# Altium schematic sheet STM32F103.SchDoc
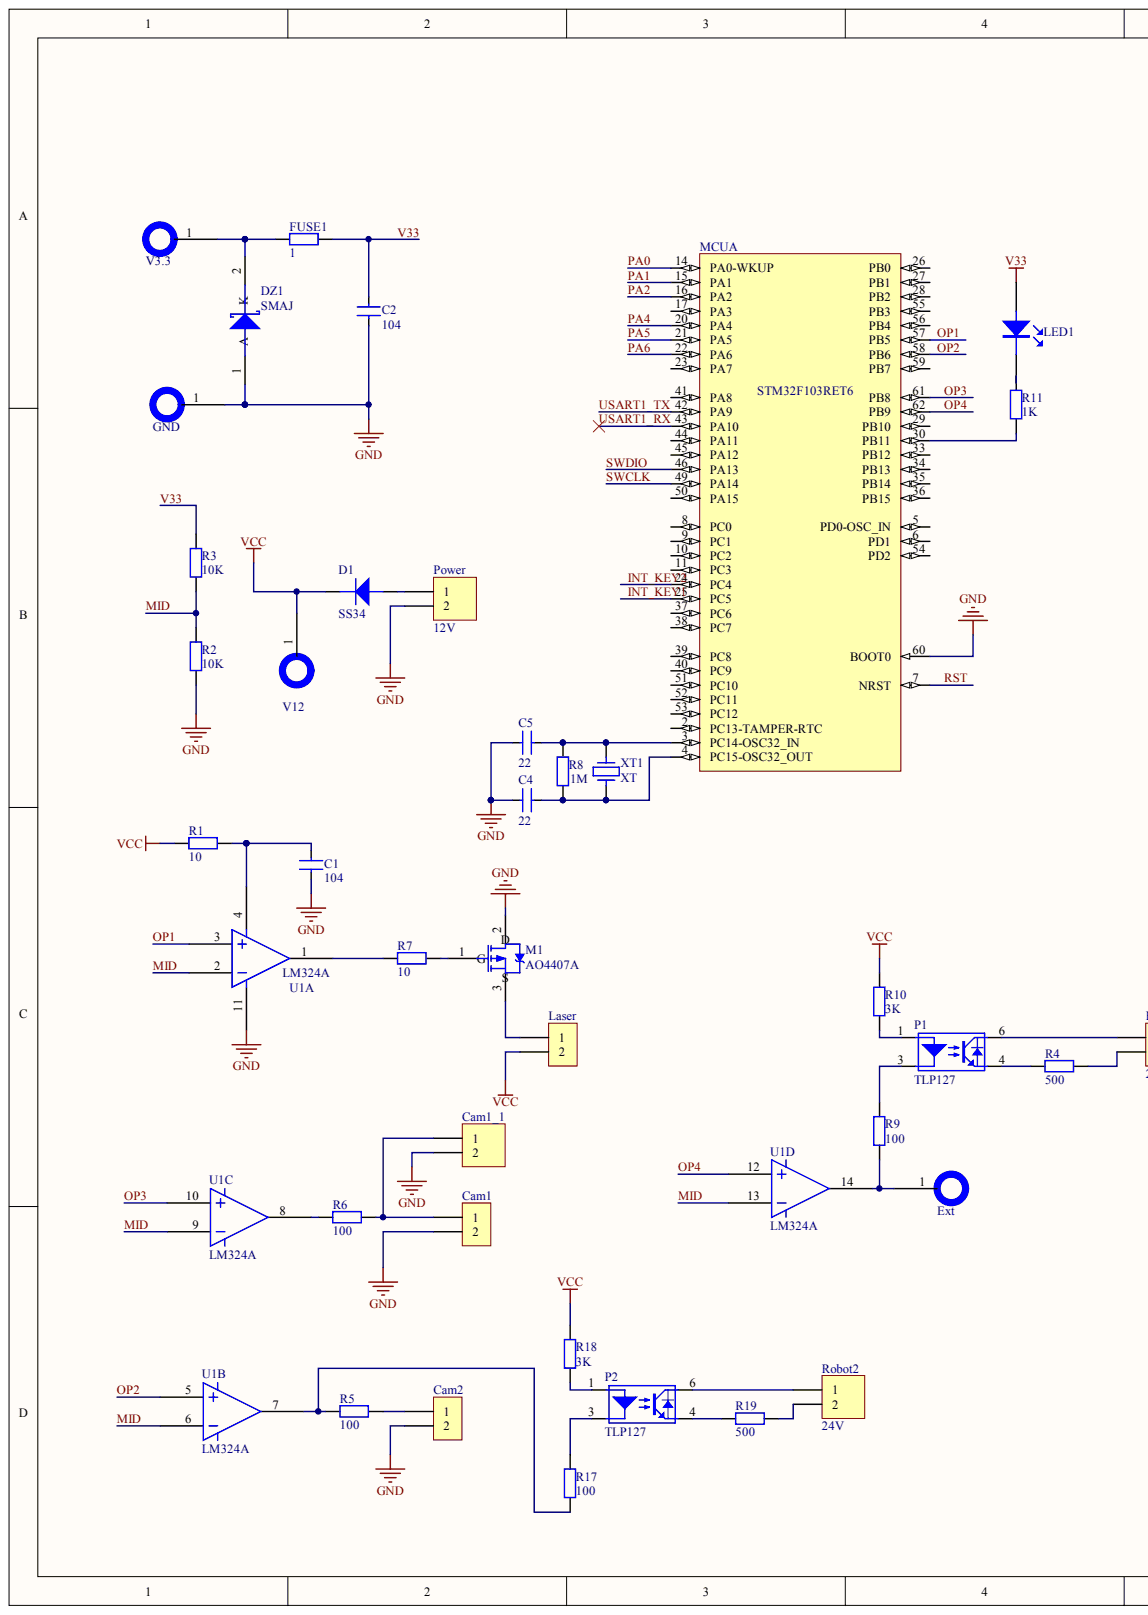
[diagram p0: STM32F103.SchDoc, left]
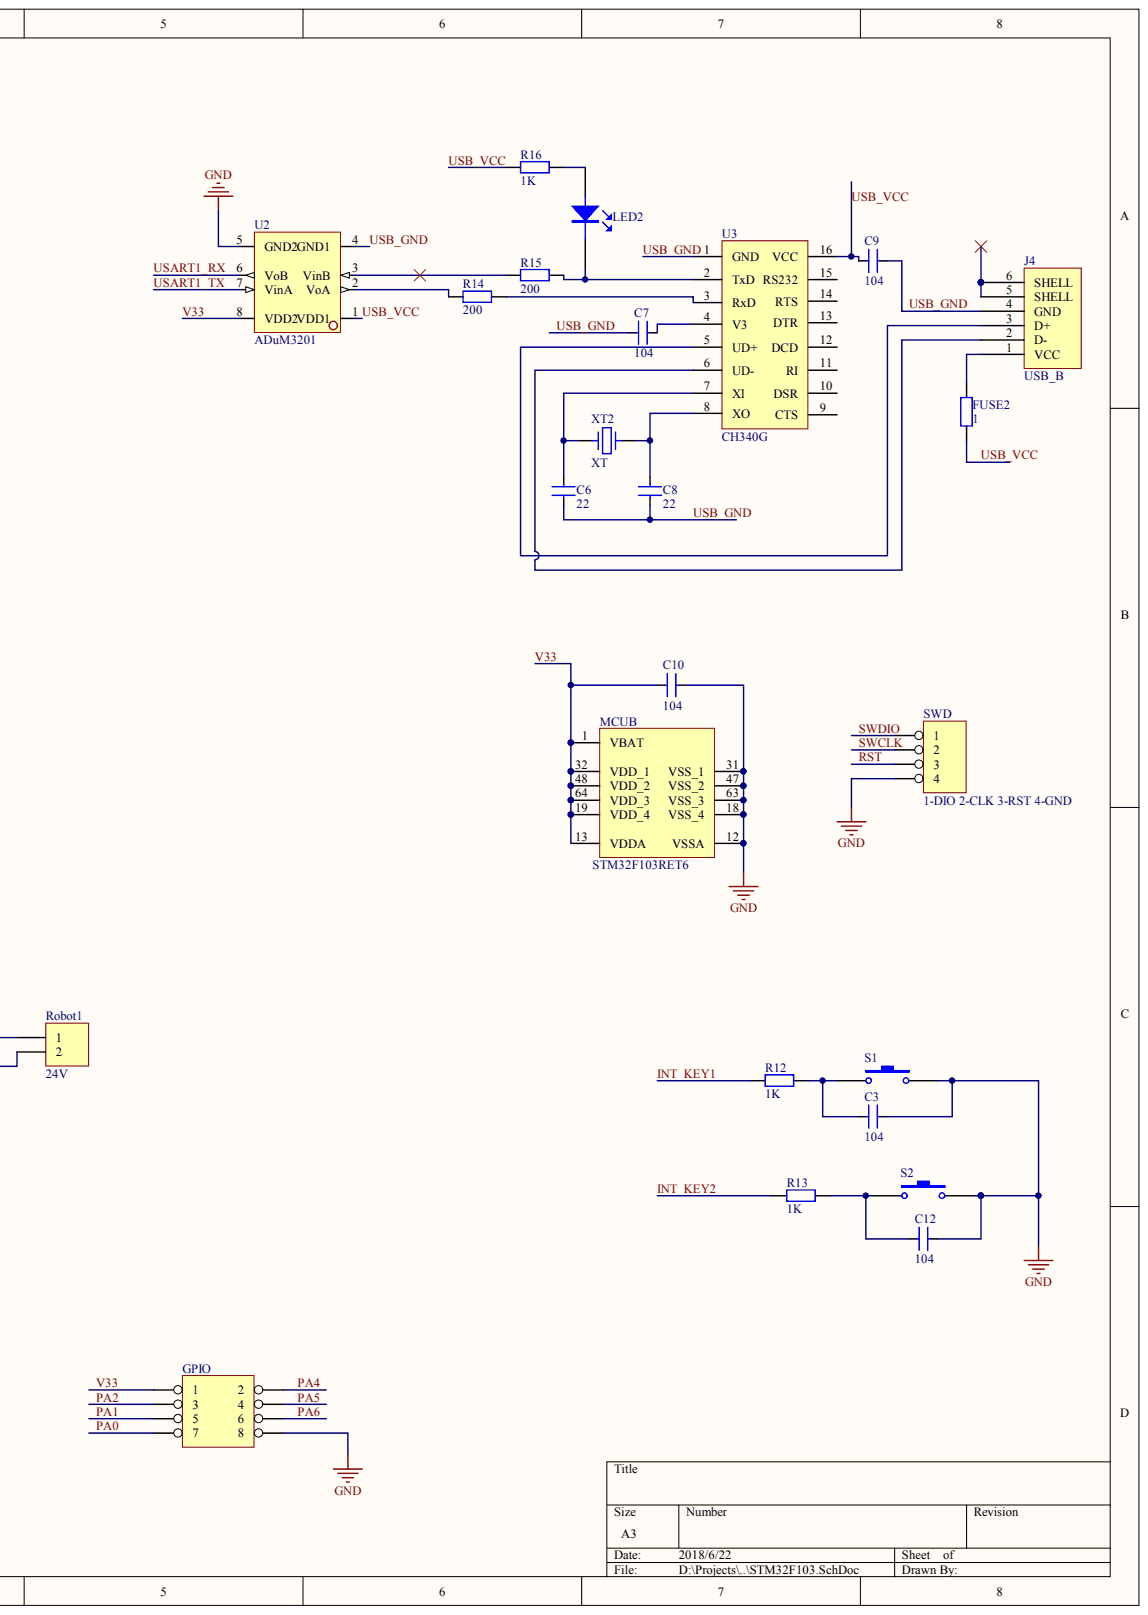
[diagram p1: STM32F103.SchDoc, right]

## Parts
C1  C  value 104
C2  C  value 104
C3  C  value 104
C4  C  value 22
C5  C  value 22
C6  C  value 22
C7  C  value 104
C8  C  value 22
C9  C  value 104
C10  C  value 104
C12  C  value 104
Cam1  Cam1
Cam1_1  (no value)
Cam2  (no value)
D1  SS34
DZ1  SMAJ
Ext  Hole  (value not drawn)
FUSE1  value 1
FUSE2  value 1
GND  Hole  (value not drawn)
GPIO  (no value)
J4  USB_B
Laser  (no value)
LED1  LED
LED2  LED
M1  AO4407A
MCU  STM32F103RET6  (parts A,B)
P1  TLP127
P2  TLP127
Power  12V
R1  value 10  (hidden comment: Res)
R2  value 10K  (hidden comment: Res)
R3  value 10K  (hidden comment: Res)
R4  value 500  (hidden comment: Res)
R5  value 100  (hidden comment: Res)
R6  value 100  (hidden comment: Res)
R7  value 10  (hidden comment: Res)
R8  value 1M  (hidden comment: Res)
R9  value 100  (hidden comment: Res)
R10  value 3K  (hidden comment: Res)
R11  value 1K  (hidden comment: Res)
R12  value 1K  (hidden comment: Res)
R13  value 1K  (hidden comment: Res)
R14  value 200  (hidden comment: Res)
R15  value 200  (hidden comment: Res)
R16  value 1K  (hidden comment: Res)
R17  value 100  (hidden comment: Res)
R18  value 3K  (hidden comment: Res)
R19  value 500  (hidden comment: Res)
Robot1  24V
Robot2  24V
S1  SW-PB  (value not drawn)
S2  SW-PB  (value not drawn)
SWD  1-DIO 2-CLK 3-RST 4-GND
U1  LM324A  (parts A,B,C,D)
U2  ADuM3201
U3  CH340G
V3.3  Hole  (value not drawn)
V12  (no value)
XT1  XT
XT2  XT

## Nets
GND: C1.2 C2.2 C3.1 C4.2 C5.2 C10.2 C12.1 Cam1.2 Cam1_1.2 Cam2.2 DZ1.1 GND.1 GPIO.8 M1.2 MCU.12(VSSA) MCU.18(VSS_4) MCU.31(VSS_1) MCU.47(VSS_2) MCU.60(BOOT0) MCU.63(VSS_3) Power.2 R2.2 S1.2 S2.2 SWD.4 U1.11 U2.5(GND2)
VCC: D1.1 Laser.2 R1.2 R10.1 R18.1 V12.1
INT_KEY1: MCU.25(PC5) R12.1
INT_KEY2: MCU.24(PC4) R13.1
MID: R2.1 R3.2 U1.2 U1.6 U1.9 U1.13
OP1: MCU.57(PB5) U1.3
OP2: MCU.58(PB6) U1.5
OP3: MCU.61(PB8) U1.10
OP4: MCU.62(PB9) U1.12
PA0: GPIO.7 MCU.14(PA0-WKUP)
PA1: GPIO.5 MCU.15(PA1)
PA2: GPIO.3 MCU.16(PA2)
PA4: GPIO.2 MCU.20(PA4)
PA5: GPIO.4 MCU.21(PA5)
PA6: GPIO.6 MCU.22(PA6)
RST: MCU.7(NRST) SWD.3
SWCLK: MCU.49(PA14) SWD.2
SWDIO: MCU.46(PA13) SWD.1
USART1_RX: MCU.43(PA10) U2.6(VoB)
USART1_TX: MCU.42(PA9) U2.7(VinA)
USB_GND: C6.1 C7.2 C8.1 C9.1 J4.4(GND) U2.4(GND1) U3.1(GND)
USB_VCC: C9.2 FUSE2.2 R16.2 U2.1(VDD1) U3.16(VCC)
V33: C2.1 C10.1 FUSE1.1 GPIO.1 LED1.A MCU.1(VBAT) MCU.13(VDDA) MCU.19(VDD_4) MCU.32(VDD_1) MCU.48(VDD_2) MCU.64(VDD_3) R3.1 U2.8(VDD2)
NetC1_1: C1.1 R1.1 U1.4
NetC3_2: C3.2 R12.2 S1.1
NetC4_1: C4.1 MCU.4(PC15-OSC32_OUT) R8.2 XT1.2
NetC5_1: C5.1 MCU.3(PC14-OSC32_IN) R8.1 XT1.1
NetC6_2: C6.2 U3.7(XI) XT2.2
NetC7_1: C7.1 U3.4(V3)
NetC8_2: C8.2 U3.8(XO) XT2.1
NetC12_2: C12.2 R13.2 S2.1
NetCam1_1: Cam1.1 Cam1_1.1 R6.1
NetCam2_1: Cam2.1 R5.1
NetD1_2: D1.2 Power.1
NetDZ1_2: DZ1.2 FUSE1.2 V3.3.1
NetExt_1: Ext.1 R9.2 U1.14
NetFUSE2_1: FUSE2.1 J4.1(VCC)
NetJ4_2: J4.2(D-) U3.6(UD-)
NetJ4_3: J4.3(D+) U3.5(UD+)
NetJ4_5: J4.5(SHELL) J4.6(SHELL)
NetLaser_1: Laser.1 M1.3
NetLED1_K: LED1.K R11.1
NetLED2_A: LED2.A R16.1
NetLED2_K: LED2.K R15.1 U3.2(TxD)
NetM1_1: M1.1 R7.1
NetMCU_30: MCU.30(PB11) R11.2
NetP1_1: P1.1 R10.2
NetP1_3: P1.3 R9.1
NetP1_4: P1.4 R4.1
NetP1_6: P1.6 Robot1.1
NetP2_1: P2.1 R18.2
NetP2_3: P2.3 R17.1
NetP2_4: P2.4 R19.1
NetP2_6: P2.6 Robot2.1
NetR4_2: R4.2 Robot1.2
NetR5_2: R5.2 R17.2 U1.7
NetR6_2: R6.2 U1.8
NetR7_2: R7.2 U1.1
NetR14_1: R14.1 U3.3(RxD)
NetR14_2: R14.2 U2.2(VoA)
NetR15_2: R15.2 U2.3(VinB)
NetR19_2: R19.2 Robot2.2

Not connected: MCU 2(PC13-TAMPER-RTC) 5(PD0-OSC_IN) 6(PD1) 8(PC0) 9(PC1) 10(PC2) 11(PC3) 17(PA3) 23(PA7) 26(PB0) 27(PB1) 28(PB2) 29(PB10) 33(PB12) 34(PB13) 35(PB14) 36(PB15) 37(PC6) 38(PC7) 39(PC8) 40(PC9) 41(PA8) 44(PA11) 45(PA12) 50(PA15) 51(PC10) 52(PC11) 53(PC12) 54(PD2) 55(PB3) 56(PB4) 59(PB7); U3 9(CTS) 10(DSR) 11(RI) 12(DCD) 13(DTR) 14(RTS) 15(RS232)

## Library data of the parts
FUSE1 FUSE2 R1-R19 = (no symbol name): Manufacturer: Generic Components; Class: Passive; SubClass: Resistor; Published: 08/06/2000; Publisher: Altium Hobart Technology Centre
C1-C10 C12 Cam1 Cam1_1 Cam2 D1 DZ1 Ext GND GPIO J4 Laser LED1 LED2 M1 MCU P1 P2 Power Robot1 Robot2 S1 S2 SWD U1-U3 V3.3 V12 XT1 XT2 = (no symbol name)
  C1-C10 C12: Published: 8-Jun-2000; LatestRevisionDate: 17-Jul-2002; LatestRevisionNote: Re-released for DXP Platform.
  Cam1_1 Power Robot1 Robot2: LatestRevisionDate: 17-Jul-2002; LatestRevisionNote: Re-released for DXP Platform.
  MCU: DatasheetVersion: rev8.0, Apr-2011; PackageVersion: rev13, Apr-2011; PackageReference: LQFP64; PackageDescription: 64-Pin Low-Profile Quad Flat Pack, 10 x 10 mm Body, 0.5 mm Pitch (LQFP64); ComponentLink1Description: Manufacturer URL; ComponentLink1URL: http://www.st.com/; ComponentLink2Description: STM32F103 Datasheet; ComponentLink2URL: http://www.st.com/internet/com/TECHNICAL_RESOURCES/TECHNICAL_LITERATURE/DATASHEET/CD00191185.pdf; ComponentLink3Description: STM32 Family Web Page; ComponentLink3URL: www.st.com/stm32
  S1 S2: Published: 24-Mar-1999; LatestRevisionDate: 17-Jul-2002; LatestRevisionNote: Re-released for DXP Platform.; PackageReference: SPST-2; PackageDescription: Switch; 2 Leads
  C1-C10 C12 Cam1_1 Power Robot1 Robot2 S1 S2: Publisher: Altium Limited
